# Altium schematic sheet Jlink_OB.SchDoc(Jlink_OB)
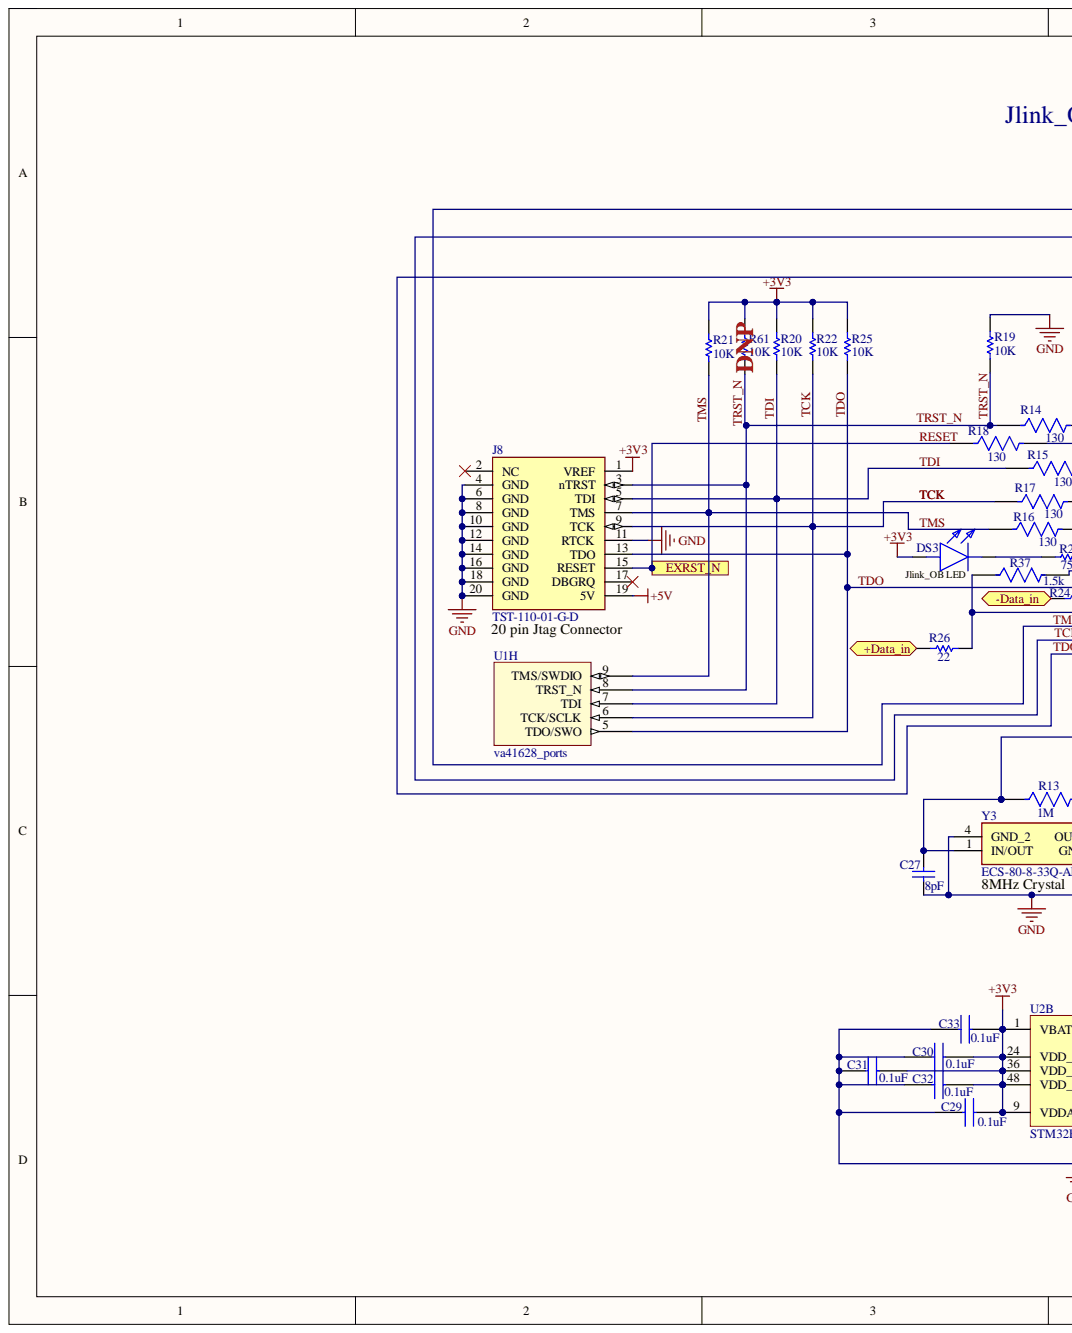
[diagram p0: Jlink_OB.SchDoc, left]
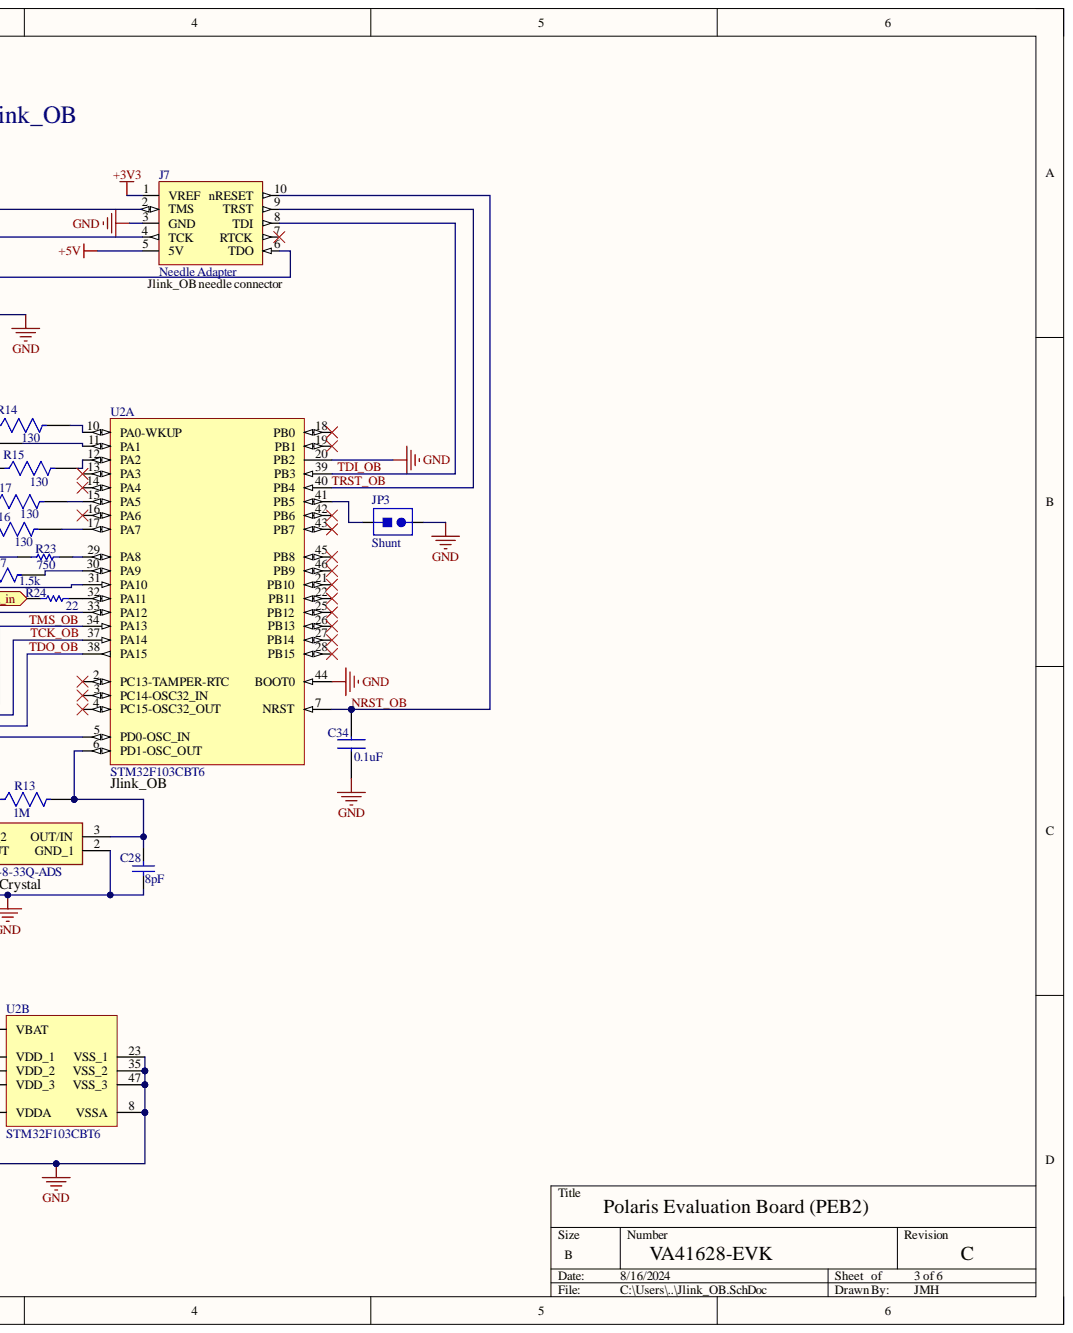
[diagram p1: Jlink_OB.SchDoc, right]

## Parts
C27  Parameter 1: 8pF  [C0603C809C5GACTU]  (hidden comment: C0402C809B5GACTU)
C28  Parameter 1: 8pF  [C0603C809C5GACTU]  (hidden comment: C0402C809B5GACTU)
C29  Parameter 1: 0.1uF  [C0603C104K3RAC7013]  (hidden comment: C0603C104K3RAC7013)
C30  Parameter 1: 0.1uF  [C0603C104K3RAC7013]  (hidden comment: C0603C104K3RAC7013)
C31  Parameter 1: 0.1uF  [C0603C104K3RAC7013]  (hidden comment: C0603C104K3RAC7013)
C32  Parameter 1: 0.1uF  [C0603C104K3RAC7013]  (hidden comment: C0603C104K3RAC7013)
C33  Parameter 1: 0.1uF  [C0603C104K3RAC7013]  (hidden comment: C0603C104K3RAC7013)
C34  Parameter 1: 0.1uF  [C0603C104K3RAC7013]  (hidden comment: C0603C104K3RAC7013)
DS3  APHD1608LCGCK  [APHD1608LCGCK]  (value not drawn)
J7  Needle Adapter  [8.06.04]
J8  TST-110-01-G-D  [TST-110-01-G-D]
JP3  Shunt  [2302-6121TG]
R13  Resistance: 1M  [CRCW08051M00FKEAC]  (hidden comment: CRCW08051M00FKEAC)
R14  CRCW0603130RFKEA  [CRCW0603130RFKEAC]  (value not drawn)
R15  CRCW0603130RFKEA  [CRCW0603130RFKEAC]  (value not drawn)
R16  CRCW0603130RFKEA  [CRCW0603130RFKEAC]  (value not drawn)
R17  CRCW0603130RFKEA  [CRCW0603130RFKEAC]  (value not drawn)
R18  CRCW0603130RFKEA  [CRCW0603130RFKEAC]  (value not drawn)
R19  Parameter 1: 10K  [CRCW060310K0FKEAC]  (hidden comment: CRCW060310K0FKEA)
R20  Parameter 1: 10K  [CRCW060310K0FKEAC]  (hidden comment: CRCW060310K0FKEA)
R21  Parameter 1: 10K  [CRCW060310K0FKEAC]  (hidden comment: CRCW060310K0FKEA)
R22  Parameter 1: 10K  [CRCW060310K0FKEAC]  (hidden comment: CRCW060310K0FKEA)
R23  CRCW0603750RFKEA  [CRCW0603750RJNEA]  (value not drawn)
R24  CRCW121022R0JNEAHP  [CRCW060322R0FKEAC]  (value not drawn)
R25  Parameter 1: 10K  [CRCW060310K0FKEAC]  (hidden comment: CRCW060310K0FKEA)
R26  CRCW121022R0JNEAHP  [CRCW060322R0FKEAC]  (value not drawn)
R37  Resistance: 1.5k  [CRCW06031K50FKEAC]  (hidden comment: CRCW06031K50FKEAC)
R61  Parameter 1: 10K  [CRCW060310K0FKEAC]  (hidden comment: CRCW060310K0FKEA)
U1  va41628_ports  [TCQFP-128-0.40-36-C]  (part H)
U2  STM32F103CBT6  [STM32F103CBT6]  (parts A,B)
Y3  ECS-80-8-33Q-ADS  [ABM8AIG-8.000MHZ-8-V1R-T]

## Ports
+Data_in, -Data_in, EXRST_N

## Nets
+3V3: C29.1 C30.1 C31.1 C32.1 C33.1 DS3.2 J7.1(VREF) J8.1(VREF) R20.1 R21.1 R22.1 R25.1 R61.1 U2.1(VBAT) U2.9(VDDA) U2.24(VDD_1) U2.36(VDD_2) U2.48(VDD_3)
+5V: J7.5(5V) J8.19(5V)
GND: C27.2 C28.2 C29.2 C30.2 C31.2 C32.2 C33.2 C34.2 J7.3(GND) J8.4(GND) J8.6(GND) J8.8(GND) J8.10(GND) J8.11(RTCK) J8.12(GND) J8.14(GND) J8.16(GND) J8.18(GND) J8.20(GND) JP3.2 R19.1 U2.8(VSSA) U2.20(PB2) U2.23(VSS_1) U2.35(VSS_2) U2.44(BOOT0) U2.47(VSS_3) Y3.2(GND_1) Y3.4(GND_2)
NRST_OB: C34.1 J7.10(nRESET) U2.7(NRST)
RESET: J8.15(RESET) R18.1 ; port EXRST_N
TCK: J8.9(TCK) R17.1 R22.2 U1.6(TCK/SCLK)
TCK_OB: J7.4(TCK) U2.37(PA14)
TDI: J8.5(TDI) R15.1 R20.2 U1.7(TDI)
TDI_OB: J7.8(TDI) U2.39(PB3)
TDO: J8.13(TDO) R25.2 U1.5(TDO/SWO) U2.31(PA10)
TDO_OB: J7.6(TDO) U2.38(PA15)
TMS: J8.7(TMS) R16.1 R21.2 U1.9(TMS/SWDIO)
TMS_OB: J7.2(TMS) U2.34(PA13)
TRST_N: J8.3(nTRST) R14.1 R19.2 R61.2 U1.8(TRST_N)
TRST_OB: J7.9(TRST) U2.40(PB4)
NetC27_1: C27.1 R13.1 U2.5(PD0-OSC_IN) Y3.1(IN/OUT)
NetC28_1: C28.1 R13.2 U2.6(PD1-OSC_OUT) Y3.3(OUT/IN)
NetDS3_1: DS3.1 R23.2
NetJP3_1: JP3.1 U2.41(PB5)
NetR14_2: R14.2 U2.10(PA0-WKUP)
NetR15_2: R15.2 U2.12(PA2)
NetR16_2: R16.2 U2.17(PA7)
NetR17_2: R17.2 U2.15(PA5)
NetR18_2: R18.2 U2.11(PA1)
NetR23_1: R23.1 U2.29(PA8)
NetR24_1: R24.1
NetR24_2: R24.2 U2.32(PA11)
NetR26_1: R26.1
NetR26_2: R26.2 R37.1 U2.33(PA12)
NetR37_2: R37.2 U2.30(PA9)

Not connected: J7 7(RTCK); J8 2(NC) 17(DBGRQ); U2 2(PC13-TAMPER-RTC) 3(PC14-OSC32_IN) 4(PC15-OSC32_OUT) 13(PA3) 14(PA4) 16(PA6) 18(PB0) 19(PB1) 21(PB10) 22(PB11) 25(PB12) 26(PB13) 27(PB14) 28(PB15) 42(PB6) 43(PB7) 45(PB8) 46(PB9)

## Library data of the parts
C27 C28 = CMP-2008-03565-1: Manufacturer: Kemet; Component Kind: Standard; Library Name: Altium Content Vault; Part Number: C0402C809B5GACTU
C29-C34 = C0603C104K3RAC7013: Capacitor; Manufacturer_Name: KEMET; Component Kind: Standard; Datasheet Link: https://www.kemet.com/specsheet/C0603C104K3RACTM; Height: 0.95mm; Library Name: SamacSys.SchLib; Manufacturer_Part_Number: C0603C104K3RAC7013
DS3 = APHD1608LCGCK: LED; Manufacturer_Name: Kingbright; Arrow Part Number: APHD1608LCGCK; Arrow Price/Stock: https://www.arrow.com/en/products/aphd1608lcgck/kingbright?region=nac; Component Kind: Standard; Datasheet Link: http://www.kingbrightusa.com/images/catalog/SPEC/APHD1608LCGCK.pdf; Height: 0.8mm; Library Name: SamacSys.SchLib; Manufacturer_Part_Number: APHD1608LCGCK; Mouser Part Number: 604-APHD1608LCGCK; Mouser Price/Stock: https://www.mouser.co.uk/ProductDetail/Kingbright/APHD1608LCGCK?qs=AQlKX63v8RvqPbV1LDSVuw%3D%3D
J7 = CMP-001-0001-3: PCB pads for JLINK 10 pin needle adaptor; Component Kind: Standard; Library Name: Vorago Tech
J8 = TST-110-01-G-D: Connector; Manufacturer_Name: SAMTEC; Component Kind: Standard; Datasheet Link: https://componentsearchengine.com//TST-110-01-G-D.pdf; Library Name: SamacSys.SchLib; Manufacturer_Part_Number: TST-110-01-G-D; Mouser2 Part Number: 200-TST11001GD; Mouser2 Price/Stock: https://www.mouser.com/Search/Refine.aspx?Keyword=200-TST11001GD
JP3 = CMP-002-00087-4: 1x2 pin header; Component Kind: Standard; Library Name: Vorago Tech
R13 = CMP-00030-23798584-1: CRCW08051M00FKEAC SMD Chip Resistor, 1 MOhm, ± 1%, 125 mW, 0805 [2012 Metric], Thick Film, General Purpose; Power Rating: 125mW; Temperature Coefficient: 100ppm/°C; Case/Package: Molded; Component Kind: Standard; Composition: Thick Film; Contact Plating: Tin; Lead Free: Lead Free; Length: 2mm; Library Name: Altium Content Vault; Max Operating Temperature: 155°C; Min Operating Temperature: -55°C; Mount: Surface Mount; NexarPartId: 23798584; RoHS: Compliant; Schedule B: 8533210045, 8533210045; Termination: Wraparound; Tolerance: 1%
R14-R18 = CMP-2000-03889-2: RES Thick Film, 130Ω, 1%, 0.1W, 100ppm/°C, 0603; Max Power Dissipation: 100mW; Resistance: 130; Voltage Rating: 75V; Case Code (Imperial): 0603; Case Code (Metric): 1608; Case/Package: 0603; Component Kind: Standard; Composition: Thick Film; Contact Plating: Tin; Depth: 0.85mm; Features: Automotive AEC-Q200; Height: 0.45mm; Lead Free: Lead Free; Length: 1.55mm; Library Name: Altium Content Vault; Max Operating Temperature: 155°C; Military Standard: Not; Min Operating Temperature: -55°C; Number of Pins: 2; Number of Terminations: 2; Package Quantity: 10; Packaging: Tape and Reel; Power Rating: 100mW; Radiation Hardening: No; Temperature Coefficient: 100ppm/°C; Termination: SMD/SMT; Tolerance: 1%; Voltage Rating (DC): 75V; Weight: 7.1E-05oz; Width: 0.85mm; Working Voltage: 75V
R19-R22 R25 R61 = CMP-1666-00005-4: Chip Resistor, 10 KOhm, +/- 1%, 100 mW, -55 to 155 degC, 0603 (1608 Metric), RoHS, Tape and Reel; Manufacturer: Vishay Semiconductor; Voltage Rating: 75 V; Component Kind: Standard; ComponentLink1Description: Manufacturer URL; ComponentLink1URL: http://www.vishay.com/; ComponentLink2Description: Datasheet; ComponentLink2URL: https://datasheet.ciiva.com/11809/0900766b80d98afc-11809037.pdf; DatasheetVersion: 06/2012; Height: 0.45 mm; Length: 1.55 mm; Library Name: Altium Content Vault; Max Operating Temperature: 155 degC; Min Operating Temperature: -55 degC; Mounting Technology: Surface Mount; Package Quantity: 5000; PackageDescription: 2-Pin Surface Mount Device, Body 1.575 x 0.85 mm; PackageReference: 0603; Packaging: Tape and Reel; REACH SVHC: Unknown; RoHSCompliant: true
R23 = CMP-2000-03568-1: Manufacturer: Vishay Dale; Res: 750; Component Kind: Standard; Library Name: Altium Content Vault; Part Number: CRCW0603750RFKEA
R24 R26 = CMP-2005-00235-1: Manufacturer: Vishay Dale; Parameter 1: 22; Component Kind: Standard; Library Name: Altium Content Vault; Part Number: CRCW121022R0JNEAHP
R37 = CMP-02407-013095-1: RES 1.5K OHM 1% 1/10W 0603; Max Power Dissipation: 100mW; Temperature Coefficient: 100ppm/°C; Case/Package: 0603; Component Kind: Standard; Contact Plating: Tin; Lead Free: Lead Free; Length: 1.55mm; Library Name: Altium Content Vault; Max Operating Temperature: 155°C; Min Operating Temperature: -55°C; Mount: Surface Mount; Number of Terminations: 2; Packaging: Tape and Reel; Power Rating: 100mW; Resistor Type: Thick Film; RoHS Compliant: Yes; Series: CRCW-C; Termination: Wraparound; Tolerance: 1%
U1 = va41628_ports: Polaris Lite 128 pin; Component Kind: Standard; Library Name: va416xx.SchLib
U2 = CMP-0237-00250-3: ARM Cortex-M3 32-bit MCU, 128 KB Flash, 20 KB Internal RAM, 37 I/Os, 48-pin LQFP, -40 to 85 degC, Tray; A/D Converter: 2x12-bit (10 Channel); FLASH Size (Prog) (kB): 128; I/Os (High Current): 37; Internal RAM Size (kB): 20; Operating Frequency(F) (Processor speed) (MHz): 72; Supply Voltage(Vcc) min (V): 2; Component Kind: Standard; ComponentLink1Description: Manufacturer URL; ComponentLink1URL: http://www.st.com/web/en/home.html; ComponentLink2Description: Datasheet; ComponentLink2URL: http://www.st.com/st-web-ui/static/active/en/resource/technical/document/datasheet/CD00161566.pdf; ComponentLink3Description: Package Specification; ComponentLink3URL: http://www.st.com/st-web-ui/static/active/en/resource/technical/document/datasheet/DM00058181.pdf; Core: ARM Cortex-M3; DatasheetVersion: Rev. 16; Library Name: Altium Content Vault; Mounting Technology: Surface Mount; PackageDescription: 48-Pin Low Profile Quad Flat Package, 7 x 7 mm Body, 0.5 mm Pitch; PackageReference: LQFP48; PackageVersion: Rev. 6, 06/2013; Packing: Tray; PartNumber: STM32F103CBT6
Y3 = ECS-80-8-33Q-ADS: Crystal or Oscillator; Manufacturer_Name: ECS; Arrow Part Number: ECS-80-8-33Q-ADS; Arrow Price/Stock: https://www.arrow.com/en/products/ecs-80-8-33q-ads/ecs-international?region=nac; Component Kind: Standard; Datasheet Link: https://ecsxtal.com/store/pdf/ECX-33Q.pdf; Height: 0.8mm; Manufacturer_Part_Number: ECS-80-8-33Q-ADS; Mouser Part Number: 520-ECS-80-8-33Q-ADS; Mouser Price/Stock: https://www.mouser.co.uk/ProductDetail/ECS/ECS-80-8-33Q-ADS?qs=hWgE7mdIu5RnrjF6MxWwaQ%3D%3D
